# Altium schematic sheet currentDriver.SchDoc
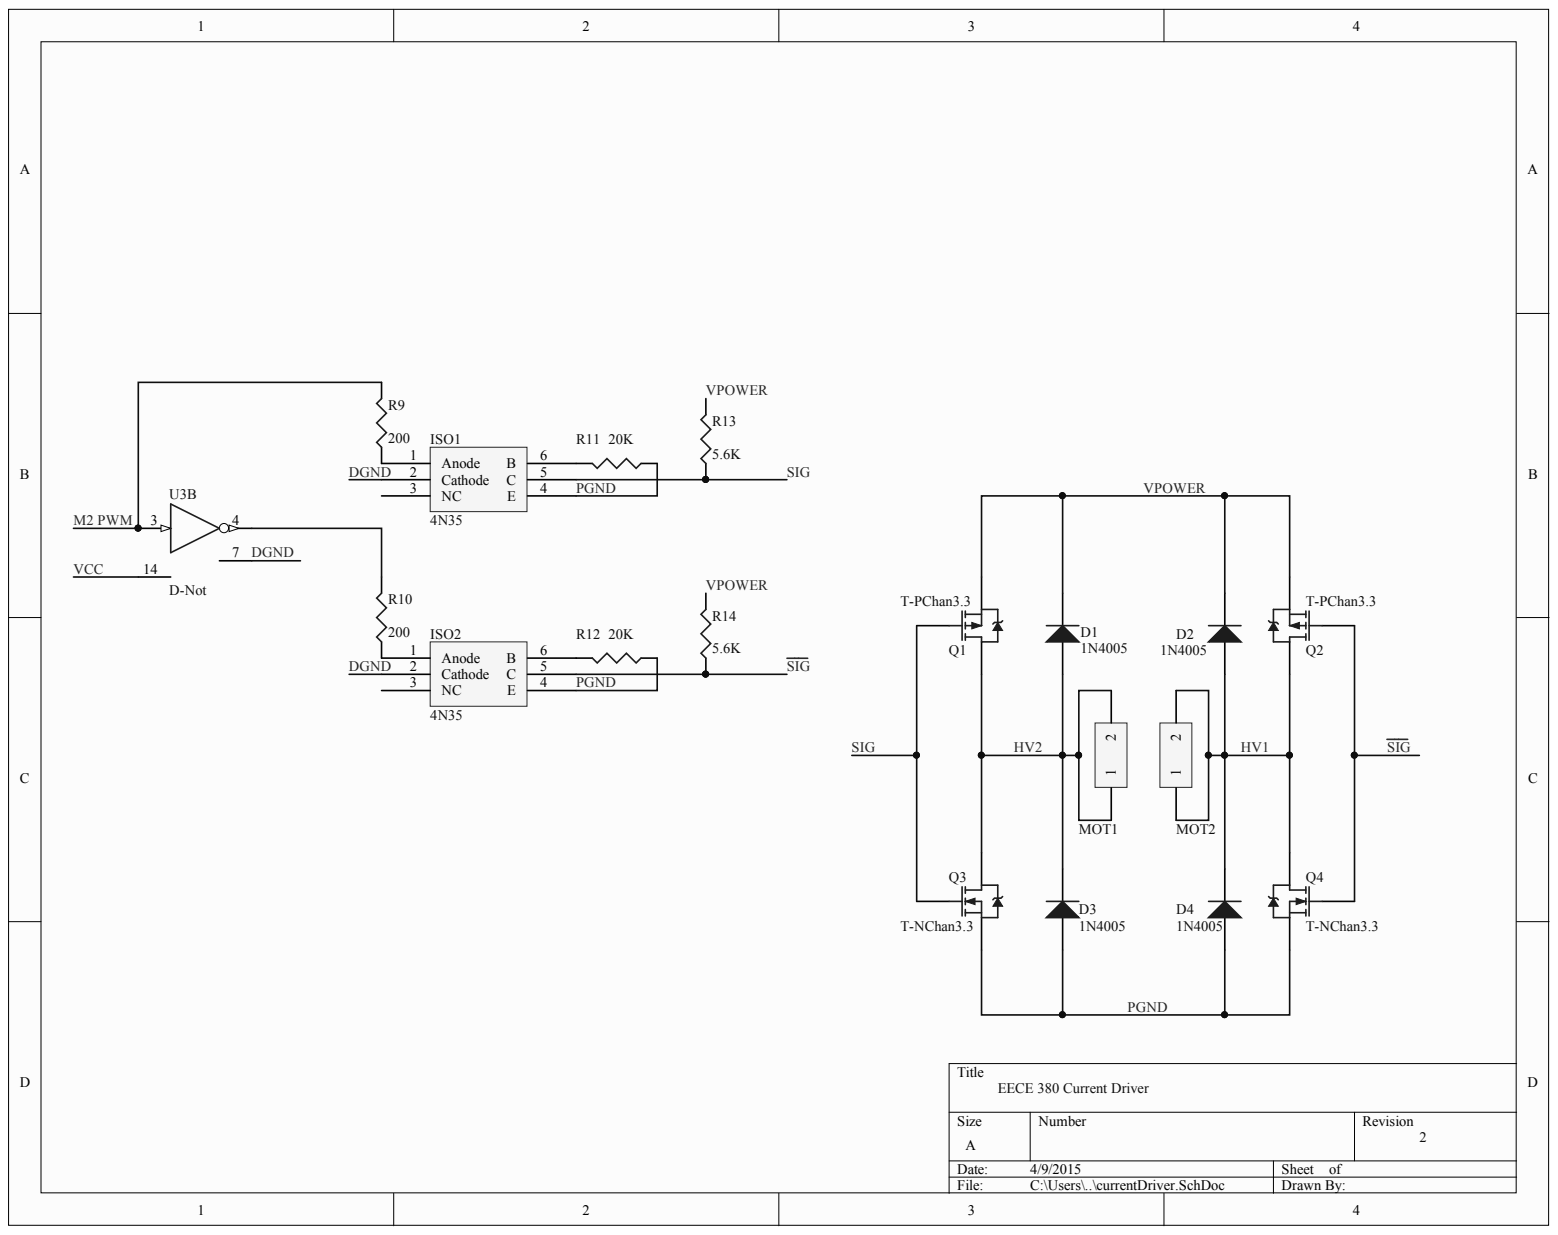
[diagram p0: currentDriver.SchDoc]

## Parts
D1  1N4005  [DO-41_XLGE1.2]
D2  1N4005  [DO-41_XLGE1.2]
D3  1N4005  [DO-41_XLGE1.2]
D4  1N4005  [DO-41_XLGE1.2]
ISO1  4N35  [DIP6LGE]
ISO2  4N35  [DIP6LGE]
MOT1  C-Ban  [Ban1cm_2XLGE1.5]  (value not drawn)
MOT2  Ban  [Ban1cm_2XLGE1.5]  (value not drawn)
Q1  T-PChan3.3  [TO-220AB]
Q2  T-PChan3.3  [TO-220AB]
Q3  T-NChan3.3  [TO-220AB]
Q4  T-NChan3.3  [TO-220AB]
R9  value 200  [Res10mm_LGE1.0]  (hidden comment: -Res)
R10  value 200  [Res10mm_LGE1.0]
R11  value 20K  [Res10mm_LGE1.0]  (hidden comment: G-Res)
R12  value 20K  [Res10mm_LGE1.0]  (hidden comment: G-Res)
R13  value 5.6K  [Res10mm_LGE1.0]  (hidden comment: G-Res)
R14  value 5.6K  [Res10mm_LGE1.0]  (hidden comment: G-Res)
U3  D-Not  [DIP14_LGE1.0]  (part B)

## Nets
DGND: ISO1.2(Cathode) ISO2.2(Cathode) U3.7
HV1: D2.1 D4.2 MOT2.1 MOT2.2 Q2.2 Q4.2
HV2: D1.1 D3.2 MOT1.1 MOT1.2 Q1.2 Q3.2
M2 PWM: R9.2 U3.3
PGND: D3.1 D4.1 ISO1.4(E) ISO2.4(E) Q3.3 Q4.3 R11.1 R12.1
~{SIG}: ISO2.5(C) Q2.1 Q4.1 R14.1
SIG: ISO1.5(C) Q1.1 Q3.1 R13.1
VCC: U3.14
VPOWER: D1.2 D2.2 Q1.3 Q2.3 R13.2 R14.2
NetISO1_1: ISO1.1(Anode) R9.1
NetISO1_6: ISO1.6(B) R11.2
NetISO2_1: ISO2.1(Anode) R10.1
NetISO2_6: ISO2.6(B) R12.2
NetR10_2: R10.2 U3.4

Not connected: ISO1 3(NC); ISO2 3(NC)

## Library data of the parts
D1-D4 = T-Diode-1N4005: diode; Code_JEDEC: DO-204-AL; Component Kind: Standard; ComponentLink1Description: Manufacturer Link; ComponentLink1URL: http://www.fairchildsemi.com/; ComponentLink2Description: Pinout Specification; ComponentLink2URL: http://www.fairchildsemi.com/ds/1N%2F1N4001.pdf; DatasheetDocument: 1998; LatestRevisionDate: 17-Jul-2002; LatestRevisionNote: Re-released for DXP Platform.; Library Name: PartsDatabaseV12.IntLib; PackageDocument: Aug-1999; PackageReference: DO-41; Published: 8-Jun-2000; Signal Integrity: 1N4005; Simulation: 1N4005; Publisher: Altium Limited
MOT1 MOT2 = C-Ban: Banana plug fem; Component Kind: Standard; LatestRevisionDate: 17-Jul-2002; LatestRevisionNote: Re-released for DXP Platform.; Library Name: PartsDatabaseV13p1.IntLib; Signal Integrity: Connector; Publisher: Altium Limited
Q1 Q2 = T-PChan3.3: P-Channel Power MOSFET; Note: Pin 4 not drawn and electrically connected to Pin 2.; Component Kind: Standard; ComponentLink1URL: https://www.fairchildsemi.com/products/discretes/fets/mosfets/FQP7P06.html?keyword=FQP7P06; Library Name: PartsDatabaseV13p1.IntLib; PackageDescription: TO, Thru-Hole, Vertical, Heatsink Mounted; 3 In-Line Leads; Pitch 2.54 mm; PackageReference: TO-220AB; Published: 4-Nov-2002; Simulation: FQP7P06
Q3 Q4 = T-NChan3.3: N-Channel Power MOSFET; Note: Pin 2 is electrically connected to pin 4.; Component Kind: Standard; ComponentLink1URL: http://www.vishay.com/product?docid=91023#psplib; LatestRevisionDate: 23-Jan-2015; Library Name: PartsDatabaseV13p1.IntLib; PackageDescription: TO, Thru-Hole, Vertical, Heatsink Mounted; 3 In-Line Leads; Pitch 2.54 mm; PackageReference: TO-220AB; Published: 23-Jan-2015; Simulation: IRF610
R9-R14 = G-Res: resistor (specify value); Component Kind: Standard; LatestRevisionDate: 29-May-2009; LatestRevisionNote: IPC-7351 Footprint Added.; Library Name: PartsDatabaseV13p1.IntLib; PackageDescription: Chip Resistor; PackageReference: J1-0603; Published: 8-Jun-2000; Signal Integrity: Res; Simulation: RESISTOR; Publisher: Altium Limited
U3 = D-Not: hex NOT gate (inverter); Component Kind: Standard; ComponentLink1Description: Manufacturer Link; ComponentLink1URL: http://www.ti.com/; ComponentLink2Description: Datasheet; ComponentLink2URL: http://www-s.ti.com/sc/ds/sn74ls04.pdf; DatasheetVersion: Mar-1988; LatestRevisionDate: 04-Mar-2005; LatestRevisionNote: Stylized 3D Model Added.; Library Name: PartsDatabaseV13p1.IntLib; PackageDescription: DIP; 14 Leads; Row Spacing 7.62 mm; Pitch 2.54 mm; PackageReference: N014; PackageVersion: Oct-1995; Published: 8-Jun-2000; Signal Integrity: SN74LS04N; Simulation: SN74LS04; Publisher: Altium Limited
ISO1 ISO2 = 4N35: Component Kind: Standard; Library Name: controllerSchLib.SchLib
